# Altium schematic sheet [15] - MPSoC CONFIG.SchDoc("[15] - MPSoC CONFIG")
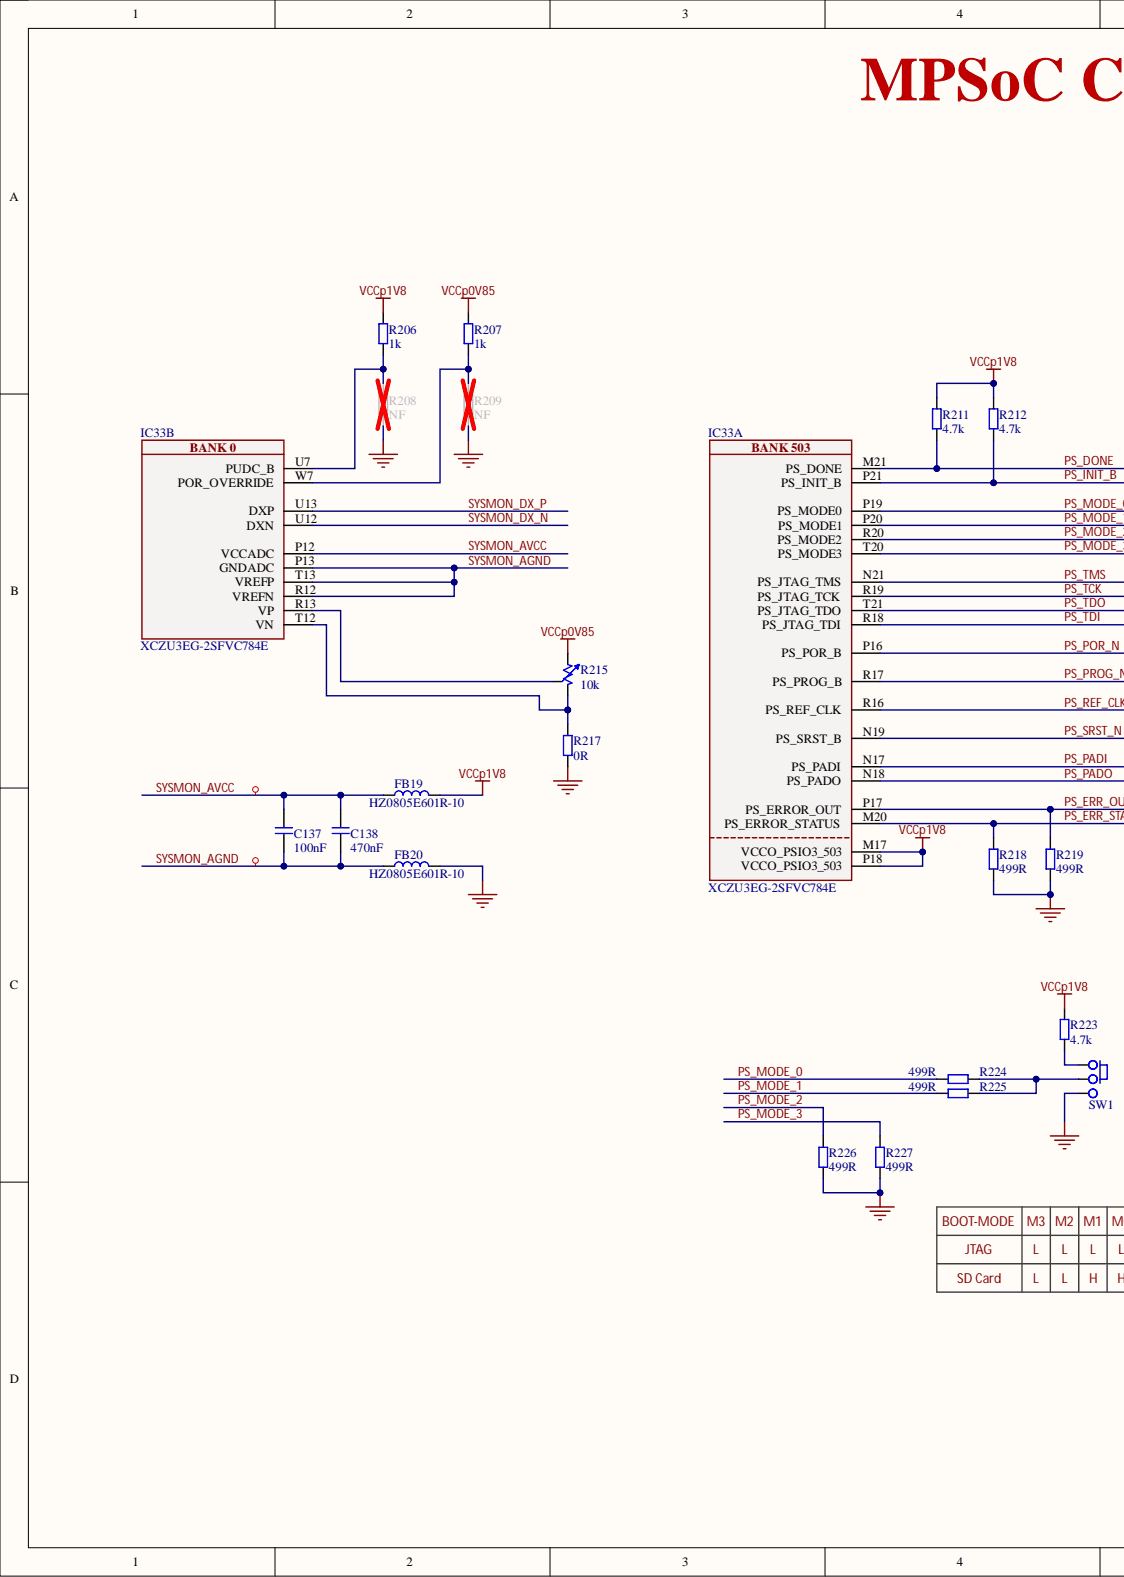
[diagram p0: [15] - MPSoC CONFIG.SchDoc, left]
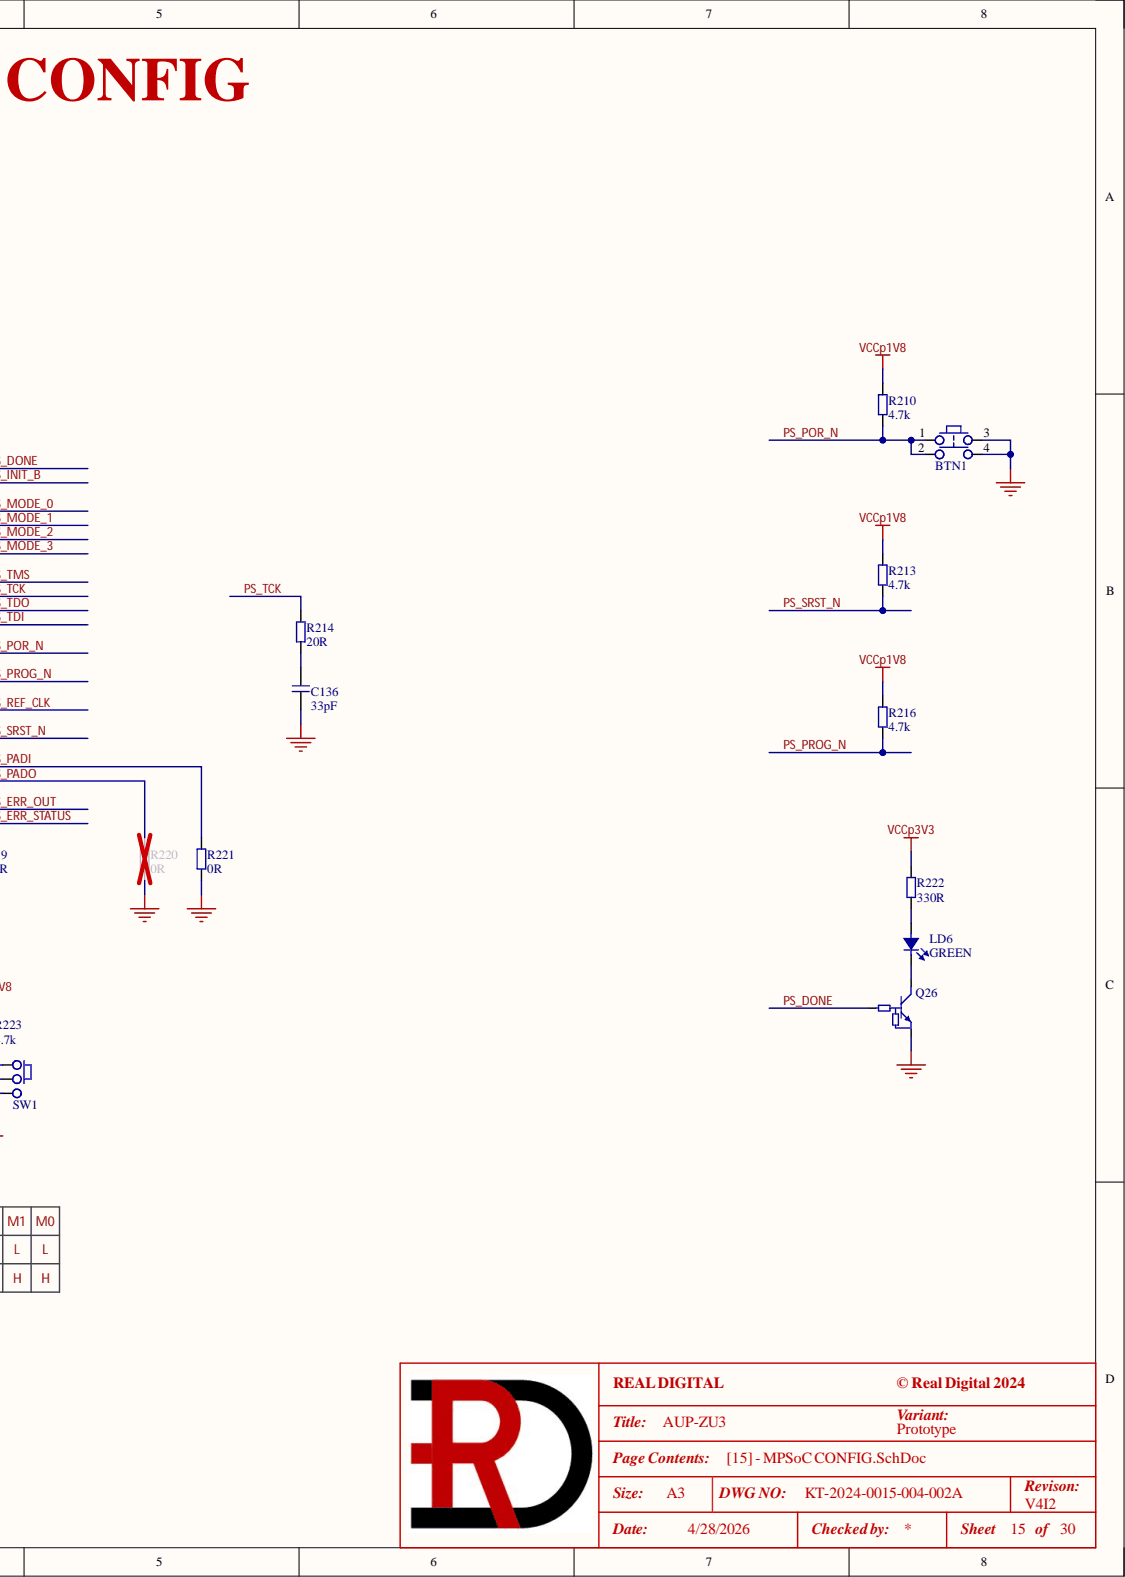
[diagram p1: [15] - MPSoC CONFIG.SchDoc, right]

## Parts
BTN1  PTA-142  [PTA-142]  (value not drawn)
C136  33pF  [C0402]
C137  100nF  [C0402]
C138  470nF  [C0402]
FB19  HZ0805E601R-10  [BLM21]
FB20  HZ0805E601R-10  [BLM21]
IC33  XCZU3EG-2SFVC784E  [SFVC784 - AMD]  (parts A,B)
LD6  GREEN  [LD0603_Green]
Q26  DTC043ZEBTL  [SC89-EMT3F]  (value not drawn)
R206  1k  [R0402]
R207  1k  [R0402]
R208  NF  [R0402]
R209  NF  [R0402]
R210  4.7k  [R0402]
R211  4.7k  [R0402]
R212  4.7k  [R0402]
R213  4.7k  [R0402]
R214  20R  [R0402]
R215  10k  [R09N]
R216  4.7k  [R0402]
R217  0R  [R0402]
R218  499R  [R0402]
R219  499R  [R0402]
R220  0R  [R0402]
R221  0R  [R0402]
R222  330R  [R0402]
R223  4.7k  [R0402]
R224  499R  [R0402]
R225  499R  [R0402]
R226  499R  [R0402]
R227  499R  [R0402]
SW1  Switch  [SW-SS12F20G4-10.5-HA-G]  (value not drawn)

## Nets
GND: BTN1.3 BTN1.4 C136.1 FB20.2 Q26.GND R208.1 R209.1 R217.1 R218.1 R219.1 R220.1 R221.1 R226.2 R227.2 SW1.3
VCCp0V85: R207.2 R215.3
VCCp1V8: FB19.2 IC33.M17(VCCO_PSIO3_503) IC33.P18(VCCO_PSIO3_503) R206.2 R210.2 R211.2 R212.2 R213.2 R216.2 R223.2
VCCp3V3: R222.2
PS_DONE: IC33.M21(PS_DONE) Q26.IN R211.1
PS_ERR_OUT: IC33.P17(PS_ERROR_OUT) R219.2
PS_ERR_STATUS: IC33.M20(PS_ERROR_STATUS) R218.2
PS_INIT_B: IC33.P21(PS_INIT_B) R212.1
PS_MODE_0: IC33.P19(PS_MODE0) R224.2
PS_MODE_1: IC33.P20(PS_MODE1) R225.2
PS_MODE_2: IC33.R20(PS_MODE2) R226.1
PS_MODE_3: IC33.T20(PS_MODE3) R227.1
PS_PADI: IC33.N17(PS_PADI) R221.2
PS_PADO: IC33.N18(PS_PADO) R220.2
PS_POR_N: BTN1.1 BTN1.2 IC33.P16(PS_POR_B) R210.1
PS_PROG_N: IC33.R17(PS_PROG_B) R216.1
PS_REF_CLK: IC33.R16(PS_REF_CLK)
PS_SRST_N: IC33.N19(PS_SRST_B) R213.1
PS_TCK: IC33.R19(PS_JTAG_TCK) R214.2
PS_TDI: IC33.R18(PS_JTAG_TDI)
PS_TDO: IC33.T21(PS_JTAG_TDO)
PS_TMS: IC33.N21(PS_JTAG_TMS)
SYSMON_AGND: C137.1 C138.1 FB20.1 IC33.P13(GNDADC) IC33.R12(VREFN) IC33.T13(VREFP)
SYSMON_AVCC: C137.2 C138.2 FB19.1 IC33.P12(VCCADC)
SYSMON_DX_N: IC33.U12(DXN)
SYSMON_DX_P: IC33.U13(DXP)
NetC136_2: C136.2 R214.1
NetIC33_R13: IC33.R13(VP) R215.2
NetIC33_T12: IC33.T12(VN) R215.1 R217.2
NetIC33_U7: IC33.U7(PUDC_B) R206.1 R208.2
NetIC33_W7: IC33.W7(POR_OVERRIDE) R207.1 R209.2
NetLD6_A: LD6.A R222.1
NetLD6_K: LD6.K Q26.OUT
NetR223_1: R223.1 SW1.1
NetR224_1: R224.1 R225.1 SW1.2

## Library data of the parts
BTN1 = Tact Switch: SWITCH TACTILE SPST-NO, 4.50mm x 4.50mm; Manufacturer 1: Pacer Technology Co., Ltd; Manufacturer 2 Part Number: TSXH-2GL; .Checked_PCB: RV; C P/N: 153-0059; LoadStatus: Load; Category: Tactile Switches; Component Kind: Standard; Library Name: KT-RealDigital.IntLib; Manufacturer 1 Part Number: PTA-142; .Checked_SCH: RV; .Creator: RV
C136 = GCM1555C1H330JA16D: CAP CER 33pF 50V C0G/NP0 0402; Manufacturer 1: Murata Electronics; .Checked_PCB: RV; C P/N: RD-111-0032; LoadStatus: Load; Tolerance: 5%; Category: Capacitors; Component Kind: Standard; Library Name: KT-RealDigital.IntLib; Manufacturer 1 Part Number: GCM1555C1H330JA16D; Temperature Coefficient: C0G/NP0; Voltage - Rated: 50V; .Checked_SCH: RV; .Creator: RV
C137 = GRM155R71E104KE14J: CAP CER 0.1uF 25V X7R 0402; Manufacturer 1: Murata Electronics; .Checked_PCB: AC; .Creator: RV; C P/N: RD-111-0006; LoadStatus: Load; Tolerance: 10%; Category: Capacitors; Component Kind: Standard; Library Name: KT-RealDigital.IntLib; Manufacturer 1 Part Number: GRM155R71E104KE14J; Temperature Coefficient: X7R; Voltage - Rated: 25V; .Checked_SCH: AC
C138 = GRM155R61H474KE11D: CAP CER 0.47uF 50V X5R 0402; Manufacturer 1: Murata Electronics; .Checked_PCB: RV; C P/N: RD-111-0033; LoadStatus: Load; Tolerance: 10%; Category: Capacitors; Component Kind: Standard; Library Name: KT-RealDigital.IntLib; Manufacturer 1 Part Number: GRM155R61H474KE11D; Temperature Coefficient: X5R; Voltage - Rated: 50V; .Checked_SCH: RV; .Creator: RV
FB19 FB20 = HZ0805E601R-10: FERRITE BEAD 600 OHM 0805 1LN; Manufacturer 1: Laird-Signal Integrity Products; .Checked_PCB: RV; C P/N: RD-131-0005; LoadStatus: Load; Category: Ferrite Beads; Component Kind: Standard; Library Name: KT-RealDigital.IntLib; Manufacturer 1 Part Number: HZ0805E601R-10; .Checked_SCH: RV; .Creator: RV
IC33 = XCZU3EG-2SFVC784E: Quad ARM® Cortex®-A53 MPCore™ with CoreSight™, Dual ARM®Cortex™-R5 with CoreSight™, ARM Mali™-400 MP2 System On Chip (SOC) IC Zynq® UltraScale+™ MPSoC EG Zynq®UltraScale+™ FPGA, 154K+ Logic Cells 533MHz, 600MHz, 1.3GHz 784-FCBGA (23x23); Manufacturer 1: AMD; .Checked_PCB: RV; C P/N: RD-126-0101-C; LoadStatus: Load; Category: IC; Component Kind: Standard; Library Name: KT-RealDigital.IntLib; Manufacturer 1 Part Number: XCZU3EG-2SFVC784E; .Checked_SCH: RV; .Creator: RV
LD6 = LED_GREEN: LED GREEN 0603; Manufacturer 1: Everlight Electronics CO., LTD; Manufacturer 1 Part Number: 19-21SYGC/S530-E4/TR8; .Checked_PCB: AC; .Creator: RV; C P/N: 121-0006; LoadStatus: Load; Category: Led; Component Kind: Standard; Library Name: KT-RealDigital.IntLib; .Checked_SCH: AC
Q26 = DTC043ZEBTL: TRANS PREBIAS NPN 50V EMT3F; Manufacturer 1: Rohm Semiconductor; .Checked_PCB: RV; C P/N: RD-121-0006; LoadStatus: Load; Category: BJTs; Component Kind: Standard; Library Name: KT-RealDigital.IntLib; Manufacturer 1 Part Number: DTC043ZEBTL; .Checked_SCH: RV; .Creator: RV
R206 R207 = RC0402FR-071KL: RES SMD 1K OHM 1% 1/16W 0402; Manufacturer 1: Yageo; .Checked_PCB: RV; C P/N: RD-101-0010; LoadStatus: Load; Tolerance: 1%/TK100; Category: Resistors; Component Kind: Standard; Library Name: KT-RealDigital.IntLib; Manufacturer 1 Part Number: RC0402FR-071KL; .Checked_SCH: RV; .Creator: RV
R208 R209 = !RES0402: Generic Resistor, 0402; .Creator: RV; LoadStatus: Load; Category: Resistors; Component Kind: Standard; Library Name: KT-RealDigital.IntLib
R210-R213 R216 R223 = RC0402FR-074K7P: RES SMD 4.7K OHM 1% 1/16W 0402; Manufacturer 1: Yageo; .Checked_PCB: AC; .Creator: RV; C P/N: 101-0129; LoadStatus: Load; Tolerance: 1%/TK100; Category: Resistors; Component Kind: Standard; Library Name: KT-RealDigital.IntLib; Manufacturer 1 Part Number: RC0402FR-074K7P; .Checked_SCH: AC
R214 = RC0402FR-0720RL: RES SMD 20 OHM 1% 1/16W 0402; Manufacturer 1: Yageo; .Checked_PCB: RV; C P/N: RD-101-0034; LoadStatus: Load; Tolerance: 1%/TK100; Category: Resistors; Component Kind: Standard; Library Name: KT-RealDigital.IntLib; Manufacturer 1 Part Number: RC0402FR-0720RL; .Checked_SCH: RV; .Creator: RV
R215 = R09N-B10K: POTENTIOMETER 10k, R09N-B10K/10φX1.8T; Manufacturer 1: Song Huei Electric CO. LTD; .Checked_PCB: RV; C P/N: 101-0210-1; LoadStatus: Load; Category: Potentiometer; Component Kind: Standard; Library Name: KT-RealDigital.IntLib; Manufacturer 1 Part Number: R09N-B10K/10φX1.8T; .Checked_SCH: RV; .Creator: RV
R217 R220 R221 = RC0402FR-070RL: RES SMD 0 OHM JUMPER 1/16W 0402; Manufacturer 1: YAGEO; .Checked_PCB: AC; .Creator: RV; C P/N: RD-101-0003; LoadStatus: Load; Category: Resistors; Component Kind: Standard; Library Name: KT-RealDigital.IntLib; Manufacturer 1 Part Number: RC0402FR-070RL; Tolerance: Jumper; .Checked_SCH: AC
R218 R219 R224-R227 = RC0402FR-07499RL: RES SMD 499 OHM 1% 1/16W 0402; Manufacturer 1: Yageo; .Checked_PCB: RV; C P/N: RD-101-0036; LoadStatus: Load; Tolerance: 1%/TK100; Category: Resistors; Component Kind: Standard; Library Name: KT-RealDigital.IntLib; Manufacturer 1 Part Number: RC0402FR-07499RL; .Checked_SCH: RV; .Creator: RV
R222 = RC0402JR-07330RL: RES SMD 330 OHM 5% 1/16W 0402; Manufacturer 1: Yageo; .Checked_PCB: AC; .Creator: RV; C P/N: 101-0112; LoadStatus: Load; Tolerance: 5%/TK100; Category: Resistors; Component Kind: Standard; Library Name: KT-RealDigital.IntLib; Manufacturer 1 Part Number: RC0402JR-07330RL; .Checked_SCH: AC
SW1 = Slide_Switch_Long_Leads: Slide Switch Long Leads (7.35mm), SPDT, Thru Hole,; Manufacturer 1: SWITRONIC INDUSTRIAL CORP.; Manufacturer 1 Part Number: SS12F20G4-10.5-HA-G; .Checked_PCB: AC; .Creator: RV; C P/N: RD-153-0002; LoadStatus: Load; Category: Switches; Component Kind: Standard; Library Name: KT-RealDigital.IntLib; .Checked_SCH: AC
